# Altium schematic sheet Sheet_5.SchDoc
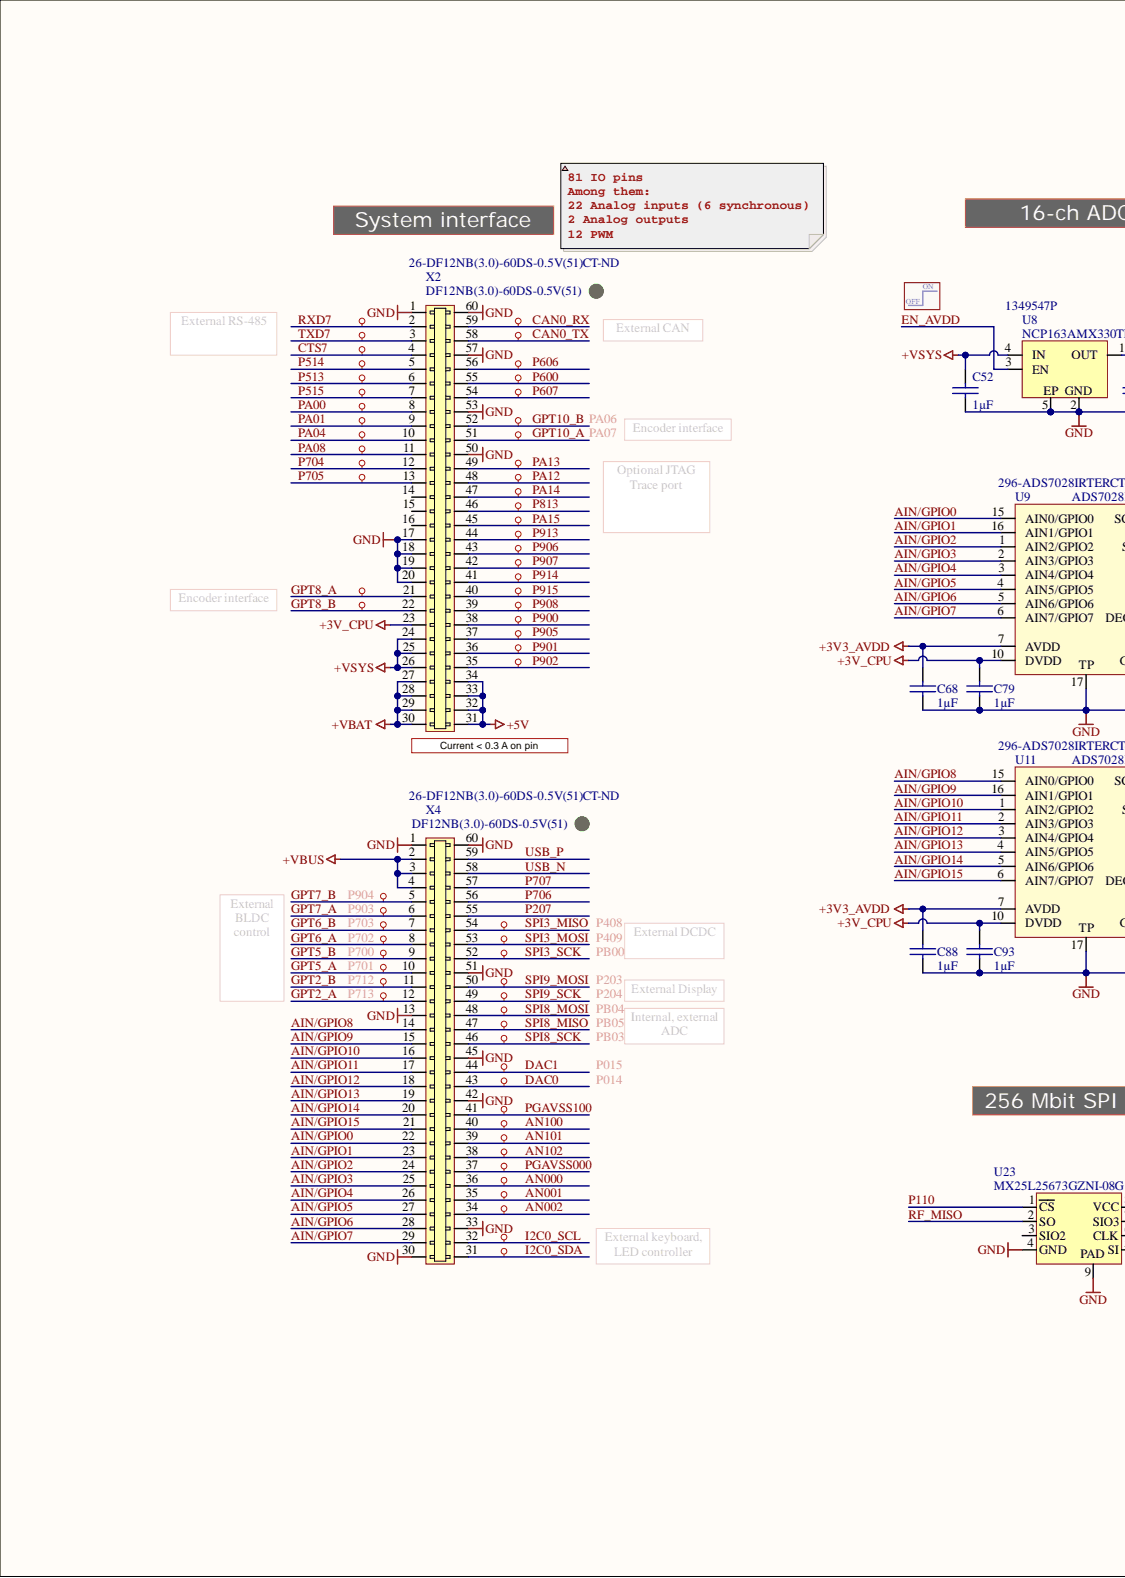
[diagram p0: Sheet_5.SchDoc, left]
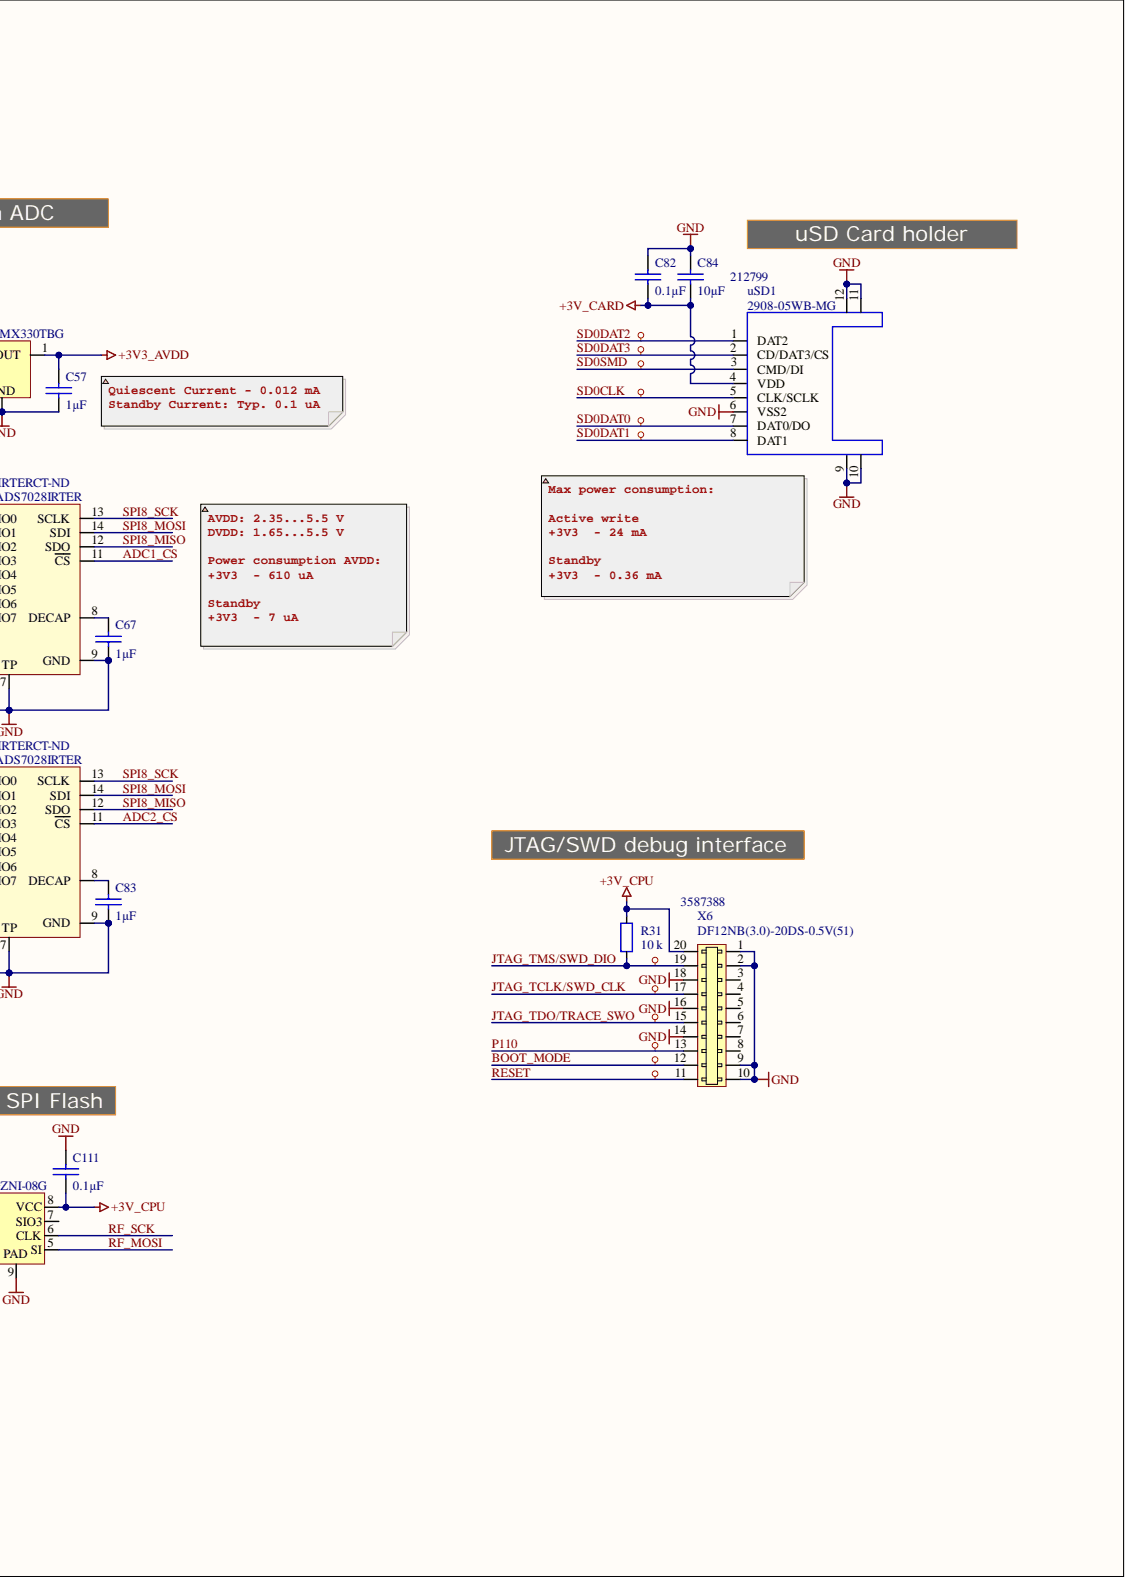
[diagram p1: Sheet_5.SchDoc, right]

## Parts
C52  value 1µF  [0402 CAP]  (hidden comment: TMK105BJ105MV-F)
C57  value 1µF  [0402 CAP]  (hidden comment: TMK105BJ105MV-F)
C67  value 1µF  [0402 CAP]  (hidden comment: TMK105BJ105MV-F)
C68  value 1µF  [0402 CAP]  (hidden comment: TMK105BJ105MV-F)
C79  value 1µF  [0402 CAP]  (hidden comment: TMK105BJ105MV-F)
C82  value 0.1µF  [0402 CAP]  (hidden comment: 0402B104K500CT)
C83  value 1µF  [0402 CAP]  (hidden comment: TMK105BJ105MV-F)
C84  value 10µF  [0603 CAP]  (hidden comment: GRT188R61C106KE13D)
C88  value 1µF  [0402 CAP]  (hidden comment: TMK105BJ105MV-F)
C93  value 1µF  [0402 CAP]  (hidden comment: TMK105BJ105MV-F)
C111  value 0.1µF  [0402 CAP]  (hidden comment: 0402B104K500CT)
R31  value 10 k  [0402]  (hidden comment: RMCF0402FT10K0)
U8  NCP163AMX330TBG  Supplier Part Number 1: 1349547P  [4-XDFN]
U9  ADS7028IRTER  PartNum: 296-ADS7028IRTERCT-ND  [WQFN-16 3x3mm TI]
U11  ADS7028IRTER  PartNum: 296-ADS7028IRTERCT-ND  [WQFN-16 3x3mm TI]
U23  MX25L25673GZNI-08G  [DFN8]
uSD1  2908-05WB-MG  Supplier Part Number 1: 212799  [2908-05WB-MG]
X2  DF12NB(3.0)-60DS-0.5V(51)  [DF12NB(3.0)-60DS-0.5V(51)]
X4  DF12NB(3.0)-60DS-0.5V(51)  [DF12NB(3.0)-60DS-0.5V(51)]
X6  DF12NB(3.0)-20DS-0.5V(51)  [DF12NB(3.0)-20DS-0.5V(51)]

## Nets
+3V3_AVDD: C57.1 C68.1 C88.1 U8.1(OUT) U9.7(AVDD) U11.7(AVDD)
+3V_CARD: C82.2 C84.2 uSD1.4(VDD)
+3V_CPU: C79.1 C93.1 C111.2 R31.2 U9.10(DVDD) U11.10(DVDD) U23.8(VCC) X2.23 X6.20
+5V: X2.31 X2.32 X2.33 X2.34
+VBAT: X2.27 X2.28 X2.29 X2.30
+VBUS: X4.2 X4.3 X4.4
+VSYS: C52.1 U8.4(IN) X2.24 X2.25 X2.26
GND: C52.2 C57.2 C67.2 C68.2 C79.2 C82.1 C83.2 C84.1 C88.2 C93.2 C111.1 U8.2 U8.5(EP) U9.9(GND) U9.17(TP) U11.9(GND) U11.17(TP) U23.4(GND) U23.9 uSD1.6(VSS2) uSD1.9 uSD1.10 uSD1.11 uSD1.12 X2.1 X2.17 X2.18 X2.19 X2.20 X2.50 X2.53 X2.57 X2.60 X4.1 X4.13 X4.30 X4.33 X4.42 X4.45 X4.51 X4.60 X6.1 X6.2 X6.9 X6.10 X6.14 X6.16 X6.18
ADC1_CS: U9.11(CS)
ADC2_CS: U11.11(CS)
AIN/GPIO0: U9.15(AIN0/GPIO0) X4.22
AIN/GPIO1: U9.16(AIN1/GPIO1) X4.23
AIN/GPIO2: U9.1(AIN2/GPIO2) X4.24
AIN/GPIO3: U9.2(AIN3/GPIO3) X4.25
AIN/GPIO4: U9.3(AIN4/GPIO4) X4.26
AIN/GPIO5: U9.4(AIN5/GPIO5) X4.27
AIN/GPIO6: U9.5(AIN6/GPIO6) X4.28
AIN/GPIO7: U9.6(AIN7/GPIO7) X4.29
AIN/GPIO8: U11.15(AIN0/GPIO0) X4.14
AIN/GPIO9: U11.16(AIN1/GPIO1) X4.15
AIN/GPIO10: U11.1(AIN2/GPIO2) X4.16
AIN/GPIO11: U11.2(AIN3/GPIO3) X4.17
AIN/GPIO12: U11.3(AIN4/GPIO4) X4.18
AIN/GPIO13: U11.4(AIN5/GPIO5) X4.19
AIN/GPIO14: U11.5(AIN6/GPIO6) X4.20
AIN/GPIO15: U11.6(AIN7/GPIO7) X4.21
AN000: X4.36
AN001: X4.35
AN002: X4.34
AN100: X4.40
AN101: X4.39
AN102: X4.38
BOOT_MODE: X6.12
CAN0_RX: X2.59
CAN0_TX: X2.58
CTS7: X2.4
DAC0: X4.43
DAC1: X4.44
EN_AVDD: U8.3(EN)
GPT2_A: X4.12
GPT2_B: X4.11
GPT5_A: X4.10
GPT5_B: X4.9
GPT6_A: X4.8
GPT6_B: X4.7
GPT7_A: X4.6
GPT7_B: X4.5
GPT8_A: X2.21
GPT8_B: X2.22
GPT10_A: X2.51
GPT10_B: X2.52
I2C0_SCL: X4.32
I2C0_SDA: X4.31
JTAG_TCLK/SWD_CLK: X6.17
JTAG_TDO/TRACE_SWO: X6.15
JTAG_TMS/SWD_DIO: R31.1 X6.19
P110: U23.1(CS) X6.13
P207: X4.55
P513: X2.6
P514: X2.5
P515: X2.7
P600: X2.55
P606: X2.56
P607: X2.54
P704: X2.12
P705: X2.13
P706: X4.56
P707: X4.57
P813: X2.46
P900: X2.38
P901: X2.36
P902: X2.35
P905: X2.37
P906: X2.43
P907: X2.42
P908: X2.39
P913: X2.44
P914: X2.41
P915: X2.40
PA00: X2.8
PA01: X2.9
PA04: X2.10
PA08: X2.11
PA12: X2.48
PA13: X2.49
PA14: X2.47
PA15: X2.45
PGAVSS000: X4.37
PGAVSS100: X4.41
RESET: X6.11
RF_MISO: U23.2(SO)
RF_MOSI: U23.5(SI)
RF_SCK: U23.6(CLK)
RXD7: X2.2
SD0CLK: uSD1.5(CLK/SCLK)
SD0DAT0: uSD1.7(DAT0/DO)
SD0DAT1: uSD1.8(DAT1)
SD0DAT2: uSD1.1(DAT2)
SD0DAT3: uSD1.2(CD/DAT3/CS)
SD0SMD: uSD1.3(CMD/DI)
SPI3_MISO: X4.54
SPI3_MOSI: X4.53
SPI3_SCK: X4.52
SPI8_MISO: U9.12(SDO) U11.12(SDO) X4.47
SPI8_MOSI: U9.14(SDI) U11.14(SDI) X4.48
SPI8_SCK: U9.13(SCLK) U11.13(SCLK) X4.46
SPI9_MOSI: X4.50
SPI9_SCK: X4.49
TXD7: X2.3
USB_N: X4.58
USB_P: X4.59
NetC67_1: C67.1 U9.8(DECAP)
NetC83_1: C83.1 U11.8(DECAP)

Not connected: U23 3(SIO2) 7(SIO3); X2 14 15 16; X6 3 4 5 6 7 8

## Library data of the parts
C52 C57 C67 C68 C79 C83 C88 C93 = TMK105BJ105MV-F: 1µF, ±20%, 25V, X5R, 0402 (1005 Metric), -55°C ~ 85°C, -, General Purpose; Manufacturer: Taiyo Yuden; PartNum: 587-3245-1-ND; Database Table Name: Cap_0402_25V; Component Kind: Standard; Distributor: Digi-Key; Features: -; Operating Temperature: -55°C ~ 85°C; Package / Case: 0402 (1005 Metric); Part Number: TMK105BJ105MV-F; PartName: TMK105BJ105MV-F; Price: 0.22000; Temperature Coefficient: X5R; Tolerance: ±20%; Voltage - Rated: 25V; Library Name: ea_PassivComps.DbLib
C82 C111 = 0402B104K500CT: 0.1µF, ±10%, 50V, X7R, 0402 (1005 Metric), -55°C ~ 125°C, -, General Purpose; Manufacturer: Walsin Technology Corporation; PartNum: 1292-1176-1-ND; Database Table Name: Cap_0402_50V; Component Kind: Standard; Distributor: Digi-Key; Features: -; Operating Temperature: -55°C ~ 125°C; Package / Case: 0402 (1005 Metric); Part Number: 0402B104K500CT; PartName: 0402B104K500CT; Price: 0.19000; Temperature Coefficient: X7R; Tolerance: ±10%; Voltage - Rated: 50V; Library Name: ea_PassivComps.DbLib
C84 = GRT188R61C106KE13D: 10µF, ±10%, 16V, X5R, 0603 (1608 Metric), -55°C ~ 85°C, -, Automotive; Manufacturer: Murata Electronics North America; PartNum: 490-12317-1-ND; Database Table Name: Cap_0603_16V; Component Kind: Standard; Distributor: Digi-Key; Features: -; Operating Temperature: -55°C ~ 85°C; Package / Case: 0603 (1608 Metric); Part Number: GRT188R61C106KE13D; PartName: GRT188R61C106KE13D; Price: 0.22770; Temperature Coefficient: X5R; Tolerance: ±10%; Voltage - Rated: 16V; Library Name: ea_PassivComps.DbLib
R31 = RMCF0402FT10K0: 10 kOhms, ±1%, 0.063W, 1/16W, Thick Film, ±100ppm/°C, 0402 (1005 Metric), -55°C ~ 155°C, Automotive AEC-Q200; Manufacturer: Stackpole Electronics Inc; PartNum: RMCF0402FT10K0CT-ND; Database Table Name: Res_0402_1%_100ppm; Voltage: 75V; Component Kind: Standard; Distributor: Digi-Key; Features: Automotive AEC-Q200; Operating Temperature: -55°C ~ 155°C; Package / Case: 0402 (1005 Metric); Part Number: RMCF0402FT10K0; PartName: RMCF0402FT10K0; Power (Watts): 0.063W, 1/16W; Price: 0.10000; Temperature Coefficient: ±100ppm/°C; Tolerance: ±1%; Library Name: ea_PassivComps.DbLib
U8 = NCP163AMX330TBG: LDO 3.3V, 250 mA, Vin < 5.5V, Iq < 20uA, -40..+125 C,  4-XDFN (1x1); Manufacturer: ON Semiconductor; PartNum: NCP163AMX330TBGOSCT-ND; Component Kind: Standard; Distributor: Digi-Key; PartName: NCP163AMX330TBG; Price: 0.41$; Supplier 1: RSComponents; Library Name: ea_IC_Linear_reg_LDO.SchLib
U9 U11 = ADS7028IRTER: 12 Bit Analog to Digital Converter 8 Input 1 SAR, 2.35V ~ 5.5V, 16-WQFN (3x3),  -40°C ~ 85°C; Manufacturer: Texas Instruments; Component Kind: Standard; Distributor: Digi-Key; PartName: ADS7028IRTER; Price: 5.1$; Supplier 1: Digi-Key; Supplier Part Number 1: 296-ADS7028IRTERCT-ND; Library Name: ea_IC_ADC.SCHLIB
U23 = MX25L25673GZNI-08G: FLASH - NOR Memory IC 256Mbit SPI 120 MHz, 2.7V ~ 3.6V, 8-WSON (6x5), -40°C ~ 85°C (TA); Manufacturer: Kioxia America, Inc.; PartNum: TC58CVG1S3HRAIG-ND; Component Kind: Standard; Distributor: Digi-Key; Price: 4.9$; Library Name: ea_IC_NOR_NAND_FLASH.SCHLIB
uSD1 = 2908-05WB-MG: microSD cadr holder; Manufacturer: 3M; Component Kind: Standard; Distributor: Farnell; PartName: 2908-05WB-MG; PartNum: 2908-05WB-MG; Price: 2.71$; Supplier 1: RSComponents; Library Name: ea_CARD_holders.SCHLIB
X2 X4 = DF12NB(3.0)-60DS-0.5V(51): 60 Position Connector Receptacle, Center Strip Contacts Surface Mount Gold, height 2.2 mm, pitch 0.5 mm, Mated Stacking Heights 3mm; Manufacturer: Hirose Electric; PartNum: 26-DF12NB(3.0)-60DS-0.5V(51)CT-ND; Component Kind: Standard; Distributor: Digi-Key; PartName: DF12NB(3.0)-60DS-0.5V(51); Price: 1.33$; Supplier 1: Digi-Key; Supplier Part Number 1: 26-DF12NB(3.0)-60DS-0.5V(51)CT-ND; System: Connector; Library Name: ea_Connectors_0.5_mm_pitch.SchLib
X6 = DF12NB(3.0)-20DS-0.5V(51): 20 Position Connector Receptacle, Center Strip Contacts Surface Mount Gold, height 2.2 mm, pitch 0.5 mm, Mated Stacking Heights 3mm; Manufacturer: Hirose Electric; PartNum: 26-DF12NB(3.0)-20DS-0.5V(51)CT-ND - Cut Tape (CT); Component Kind: Standard; Distributor: Digi-Key; PartName: DF12NB(3.0)-20DS-0.5V(51); Price: 064$; Supplier 1: Farnell; Supplier Part Number 1: 3587388; System: Connector; Library Name: ea_Connectors_0.5_mm_pitch.SchLib
